# Altium schematic sheet 03-10715_Rev_0.1_Sheet_3.SchDoc
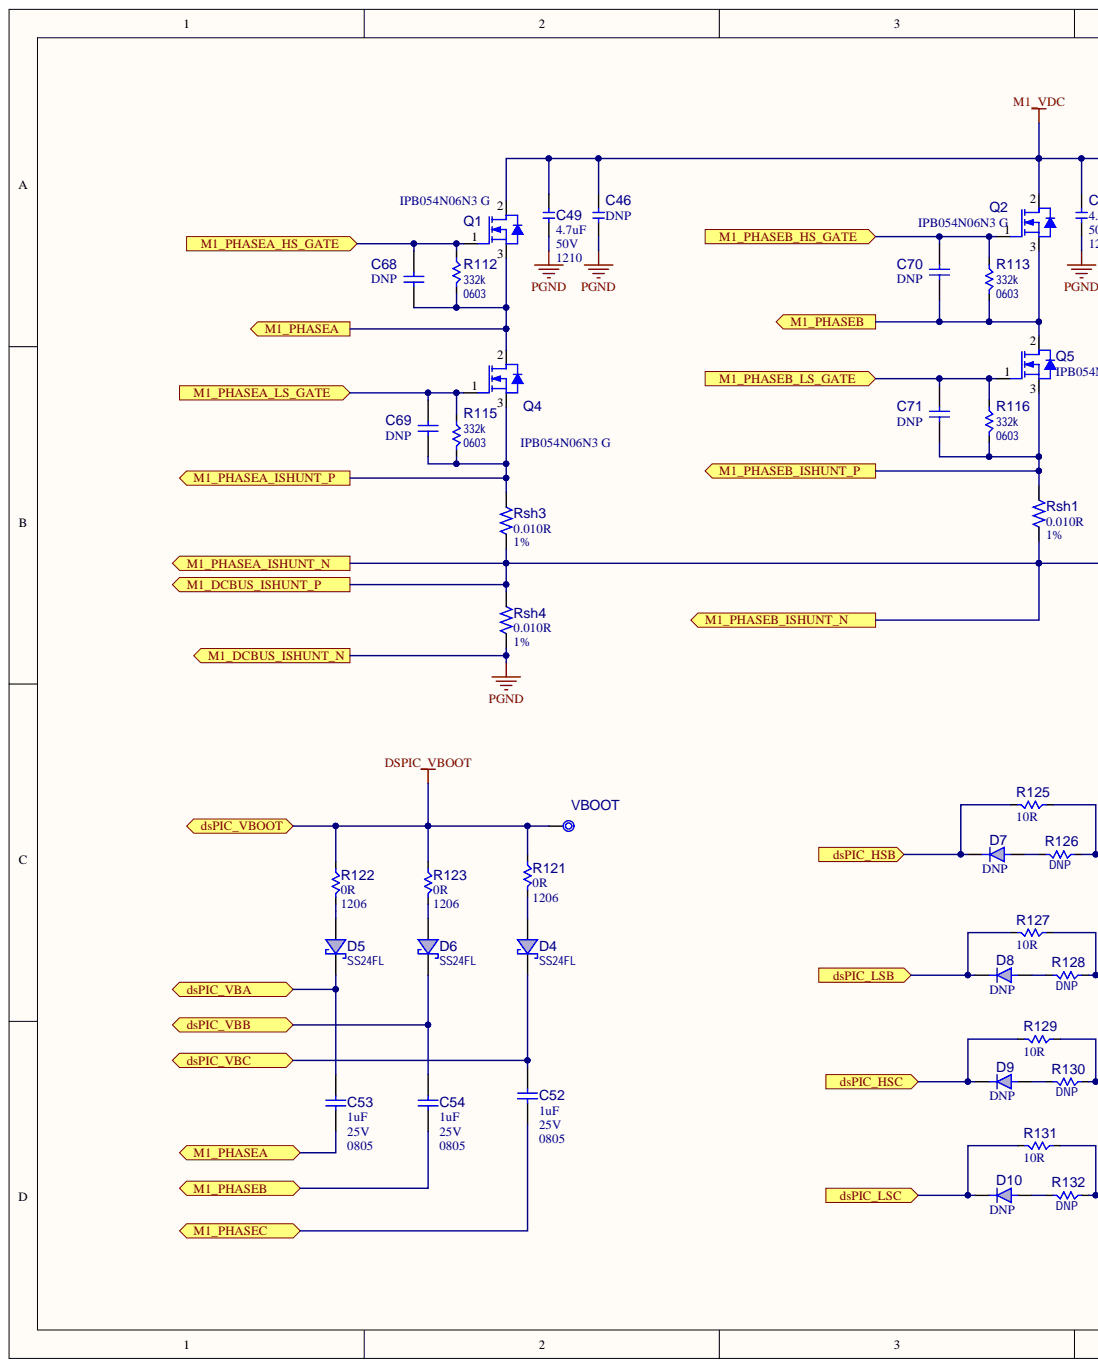
[diagram p0: 03-10715_Rev_0.1_Sheet_3.SchDoc, left]
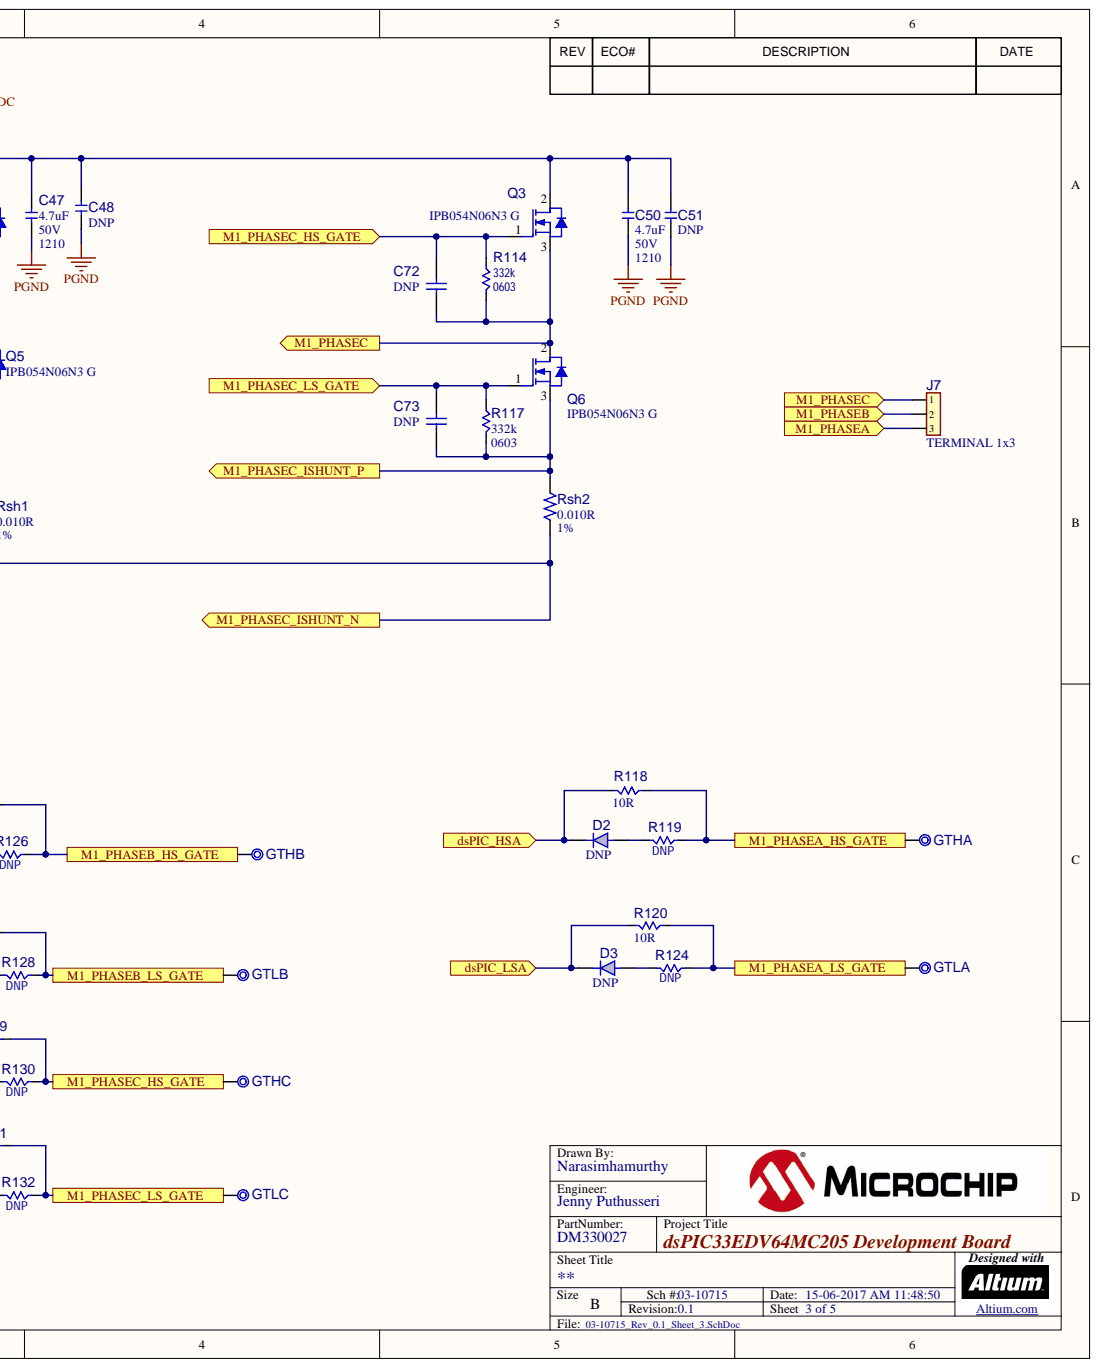
[diagram p1: 03-10715_Rev_0.1_Sheet_3.SchDoc, right]

## Parts
C46  value 4.7uF  Populated: DNP  [CAP_C_1210]  (hidden comment: Capacitor NP)
C47  value 4.7uF  Package: 1210  Voltage: 50V  [CAP_C_1210]  (hidden comment: Capacitor NP)
C48  Populated: DNP  [CAP_C_1210]  (hidden comment: Capacitor NP)
C49  value 4.7uF  Package: 1210  Voltage: 50V  [CAP_C_1210]  (hidden comment: Capacitor NP)
C50  value 4.7uF  Package: 1210  Voltage: 50V  [CAP_C_1210]  (hidden comment: Capacitor NP)
C51  value 4.7uF  Package: 1210  Populated: DNP  [CAP_C_1210]  (hidden comment: Capacitor NP)
C52  value 1uF  Package: 0805  Voltage: 25V  [CAP_C_0805]  (hidden comment: Capacitor NP)
C53  value 1uF  Package: 0805  Voltage: 25V  [CAP_C_0805]  (hidden comment: Capacitor NP)
C54  value 1uF  Package: 0805  Voltage: 25V  [CAP_C_0805]  (hidden comment: Capacitor NP)
C68  Populated: DNP  [CAP_C_0603]  (hidden comment: Capacitor NP)
C69  Populated: DNP  [CAP_C_0603]  (hidden comment: Capacitor NP)
C70  Populated: DNP  [CAP_C_0603]  (hidden comment: Capacitor NP)
C71  Populated: DNP  [CAP_C_0603]  (hidden comment: Capacitor NP)
C72  Populated: DNP  [CAP_C_0603]  (hidden comment: Capacitor NP)
C73  Populated: DNP  [CAP_C_0603]  (hidden comment: Capacitor NP)
D2  Populated: DNP  [SOD-323]  (hidden comment: Diode Single)
D3  Populated: DNP  [SOD-323]  (hidden comment: Diode Single)
D4  value SS24FL  Manufacturer Part Number 1: SS24FL  [SOD-123]  (hidden comment: Diode Schottky)
D5  value SS24FL  Manufacturer Part Number 1: SS24FL  [SOD-123]  (hidden comment: Diode Schottky)
D6  value SS24FL  Manufacturer Part Number 1: SS24FL  [SOD-123]  (hidden comment: Diode Schottky)
D7  Populated: DNP  [SOD-323]  (hidden comment: Diode Single)
D8  Populated: DNP  [SOD-323]  (hidden comment: Diode Single)
D9  Populated: DNP  [SOD-323]  (hidden comment: Diode Single)
D10  Populated: DNP  [SOD-323]  (hidden comment: Diode Single)
GTHA  Test Point No BOM  [TP_1.2x0.7]  (value not drawn)  (no BOM)
GTHB  Test Point No BOM  [TP_1.2x0.7]  (value not drawn)  (no BOM)
GTHC  Test Point No BOM  [TP_1.2x0.7]  (value not drawn)  (no BOM)
GTLA  Test Point No BOM  [TP_1.2x0.7]  (value not drawn)  (no BOM)
GTLB  Test Point No BOM  [TP_1.2x0.7]  (value not drawn)  (no BOM)
GTLC  Test Point No BOM  [TP_1.2x0.7]  (value not drawn)  (no BOM)
J7  value TERMINAL 1x3  [CON_TERMINAL_5_1x3_TH_RA_OST_OSTVI030152]  (hidden comment: Header 1x3)
Q1  value IPB054N06N3 G  Manufacturer Part Number 1: IPB054N06N3 G  [TO-263-3]  (hidden comment: Transistor MOS-N GDS)
Q2  value IPB054N06N3 G  Manufacturer Part Number 1: IPB054N06N3 G  [TO-263-3]  (hidden comment: Transistor MOS-N GDS)
Q3  value IPB054N06N3 G  Manufacturer Part Number 1: IPB054N06N3 G  [TO-263-3]  (hidden comment: Transistor MOS-N GDS)
Q4  value IPB054N06N3 G  [TO-263-3]  (hidden comment: Transistor MOS-N GDS)
Q5  value IPB054N06N3 G  [TO-263-3]  (hidden comment: Transistor MOS-N GDS)
Q6  value IPB054N06N3 G  [TO-263-3]  (hidden comment: Transistor MOS-N GDS)
R112  value 332k  Package: 0603  [RES_C_0603]  (hidden comment: Resistor)
R113  value 332k  Package: 0603  [RES_C_0603]  (hidden comment: Resistor)
R114  value 332k  Package: 0603  [RES_C_0603]  (hidden comment: Resistor)
R115  value 332k  Package: 0603  [RES_C_0603]  (hidden comment: Resistor)
R116  value 332k  Package: 0603  [RES_C_0603]  (hidden comment: Resistor)
R117  value 332k  Package: 0603  [RES_C_0603]  (hidden comment: Resistor)
R118  value 10R  [RES_C_0603]  (hidden comment: RC_0805)
R119  Populated: DNP  [RES_C_0603]  (hidden comment: RC_0805_No Load)
R120  value 10R  [RES_C_0603]  (hidden comment: RC_0805)
R121  value 0R  Package: 1206  [RES_C_1206]  (hidden comment: Resistor)
R122  value 0R  Package: 1206  [RES_C_1206]  (hidden comment: Resistor)
R123  value 0R  Package: 1206  [RES_C_1206]  (hidden comment: Resistor)
R124  Populated: DNP  [RES_C_0603]  (hidden comment: RC_0805_No Load)
R125  value 10R  [RES_C_0603]  (hidden comment: RC_0805)
R126  Populated: DNP  [RES_C_0603]  (hidden comment: RC_0805_No Load)
R127  value 10R  [RES_C_0603]  (hidden comment: RC_0805)
R128  Populated: DNP  [RES_C_0603]  (hidden comment: RC_0805_No Load)
R129  value 10R  [RES_C_0603]  (hidden comment: RC_0805)
R130  Populated: DNP  [RES_C_0603]  (hidden comment: RC_0805_No Load)
R131  value 10R  [RES_C_0603]  (hidden comment: RC_0805)
R132  Populated: DNP  [RES_C_0603]  (hidden comment: RC_0805_No Load)
Rsh1  value 0.010R  Tolerance: 1%  [RES_TTE_OARS-3]  (hidden comment: Resistor)
Rsh2  value 0.010R  Tolerance: 1%  [RES_TTE_OARS-3]  (hidden comment: Resistor)
Rsh3  value 0.010R  Tolerance: 1%  [RES_TTE_OARS-3]  (hidden comment: Resistor)
Rsh4  value 0.010R  Tolerance: 1%  [RES_TTE_OARS-3]  (hidden comment: Resistor)
VBOOT  VBOOT  [TP_1.2x0.7]  (no BOM)

## Ports
dsPIC_HSA, dsPIC_HSB, dsPIC_HSC, dsPIC_LSA, dsPIC_LSB, dsPIC_LSC, dsPIC_VBA, dsPIC_VBB, dsPIC_VBC, dsPIC_VBOOT, M1_DCBUS_ISHUNT_N, M1_DCBUS_ISHUNT_P, M1_PHASEA, M1_PHASEA_HS_GATE, M1_PHASEA_ISHUNT_N, M1_PHASEA_ISHUNT_P, M1_PHASEA_LS_GATE, M1_PHASEB, M1_PHASEB_HS_GATE, M1_PHASEB_ISHUNT_N, M1_PHASEB_ISHUNT_P, M1_PHASEB_LS_GATE, M1_PHASEC, M1_PHASEC_HS_GATE, M1_PHASEC_ISHUNT_N, M1_PHASEC_ISHUNT_P, M1_PHASEC_LS_GATE

## Nets
M1_VDC: C46.1 C47.1 C48.1 C49.1 C50.1 C51.1 Q1.2 Q2.2 Q3.2
dsPIC_HSA: D2.2 R118.1 ; port dsPIC_HSA
dsPIC_HSB: D7.2 R125.1 ; port dsPIC_HSB
dsPIC_HSC: D9.2 R129.1 ; port dsPIC_HSC
dsPIC_LSA: D3.2 R120.1 ; port dsPIC_LSA
dsPIC_LSB: D8.2 R127.1 ; port dsPIC_LSB
dsPIC_LSC: D10.2 R131.1 ; port dsPIC_LSC
dsPIC_VBA: C53.2 D5.2 ; port dsPIC_VBA
dsPIC_VBB: C54.2 D6.2 ; port dsPIC_VBB
dsPIC_VBC: C52.2 D4.2 ; port dsPIC_VBC
DSPIC_VBOOT: R121.2 R122.2 R123.2 VBOOT.1 ; port dsPIC_VBOOT
M1_DCBUS_ISHUNT_P: Rsh1.1 Rsh2.1 Rsh3.1 Rsh4.2 ; port M1_DCBUS_ISHUNT_P, port M1_PHASEA_ISHUNT_N, port M1_PHASEB_ISHUNT_N, port M1_PHASEC_ISHUNT_N
M1_PHASEA: C53.1 C68.1 J7.3 Q1.3 Q4.2 R112.2 ; port M1_PHASEA
M1_PHASEA_HS_GATE: C68.2 GTHA.1 Q1.1 R112.1 R118.2 R119.2 ; port M1_PHASEA_HS_GATE
M1_PHASEA_ISHUNT_P: C69.1 Q4.3 R115.2 Rsh3.2 ; port M1_PHASEA_ISHUNT_P
M1_PHASEA_LS_GATE: C69.2 GTLA.1 Q4.1 R115.1 R120.2 R124.2 ; port M1_PHASEA_LS_GATE
M1_PHASEB: C54.1 C70.1 J7.2 Q2.3 Q5.2 R113.2 ; port M1_PHASEB
M1_PHASEB_HS_GATE: C70.2 GTHB.1 Q2.1 R113.1 R125.2 R126.2 ; port M1_PHASEB_HS_GATE
M1_PHASEB_ISHUNT_P: C71.1 Q5.3 R116.2 Rsh1.2 ; port M1_PHASEB_ISHUNT_P
M1_PHASEB_LS_GATE: C71.2 GTLB.1 Q5.1 R116.1 R127.2 R128.2 ; port M1_PHASEB_LS_GATE
M1_PHASEC: C52.1 C72.1 J7.1 Q3.3 Q6.2 R114.2 ; port M1_PHASEC
M1_PHASEC_HS_GATE: C72.2 GTHC.1 Q3.1 R114.1 R129.2 R130.2 ; port M1_PHASEC_HS_GATE
M1_PHASEC_ISHUNT_P: C73.1 Q6.3 R117.2 Rsh2.2 ; port M1_PHASEC_ISHUNT_P
M1_PHASEC_LS_GATE: C73.2 GTLC.1 Q6.1 R117.1 R131.2 R132.2 ; port M1_PHASEC_LS_GATE
PGND: C46.2 C47.2 C48.2 C49.2 C50.2 C51.2 Rsh4.1 ; port M1_DCBUS_ISHUNT_N
NetD2_1: D2.1 R119.1
NetD3_1: D3.1 R124.1
NetD4_1: D4.1 R121.1
NetD5_1: D5.1 R122.1
NetD6_1: D6.1 R123.1
NetD7_1: D7.1 R126.1
NetD8_1: D8.1 R128.1
NetD9_1: D9.1 R130.1
NetD10_1: D10.1 R132.1

## Library data of the parts
C46 C51 = CAP1252: Capacitor; Manufacturer 1: Murata Electronics North America; Manufacturer Part Number 1: GRM32ER71H475KA88L; Description_: CAP CER 4.7uF 50V 10% X7R SMD 1210; Owner: Stephen Humberd; Temperature Coeffcient: X7R; Tolerance: 10%; Type: Ceramic; Voltage: 50V; Category: Capacitor; Component Kind: Standard; ComponentLink1Description: Datasheet; ComponentLink1URL: https://chn-vm-apps.microchip.com/mchprepo/parts/Datasheets/L0005E.pdf; Database Table Name: Capacitor; Library Name: MCHP-CDB.DbLib; MCHP-ID: CAP1252; Operating Temperature: -55°C ~ 125°C; Quantity Override: 1; Revision: A; Status: Design; Supplier 1: Digi-Key; Supplier Part Number 1: 490-1864-1-ND
  C46: Package: 1210
C47 C49 C50 = CAP1252: Capacitor; Manufacturer 1: Murata Electronics North America; Manufacturer Part Number 1: GRM32ER71H475KA88L; Description_: CAP CER 4.7uF 50V 10% X7R SMD 1210; Owner: Stephen Humberd; Populated: YES; Temperature Coeffcient: X7R; Tolerance: 10%; Type: Ceramic; Category: Capacitor; Component Kind: Standard; ComponentLink1Description: Datasheet; ComponentLink1URL: https://chn-vm-apps.microchip.com/mchprepo/parts/Datasheets/L0005E.pdf; Database Table Name: Capacitor; Library Name: MCHP-CDB.DbLib; MCHP-ID: CAP1252; Operating Temperature: -55°C ~ 125°C; Quantity Override: 1; Revision: A; Status: Design; Supplier 1: Digi-Key; Supplier Part Number 1: 490-1864-1-ND
C48 = CAP1252: Capacitor; Manufacturer 1: Murata Electronics North America; Manufacturer Part Number 1: GRM32ER71H475KA88L; Description_: CAP CER 4.7uF 50V 10% X7R SMD 1210; Owner: Stephen Humberd; Temperature Coeffcient: X7R; Tolerance: 10%; Type: Ceramic; Value: 4.7uF; Voltage: 50V; Category: Capacitor; Component Kind: Standard; ComponentLink1Description: Datasheet; ComponentLink1URL: https://chn-vm-apps.microchip.com/mchprepo/parts/Datasheets/L0005E.pdf; Database Table Name: Capacitor; Library Name: MCHP-CDB.DbLib; MCHP-ID: CAP1252; Operating Temperature: -55°C ~ 125°C; Package: 1210; Quantity Override: 1; Revision: A; Status: Design; Supplier 1: Digi-Key; Supplier Part Number 1: 490-1864-1-ND
C52-C54 = CAP1211: Capacitor; Manufacturer 1: AVX; Manufacturer Part Number 1: 08053C105K4Z2A; Description_: CAP CER 1uF 25V 10% X7R SMD 0805; Dev Tools Status: Production; Owner: Tad Ahlvers; Populated: YES; Temperature Coeffcient: X7R; Tolerance: 10%; Type: Ceramic; Category: Capacitor; Component Kind: Standard; ComponentLink1Description: SVN Datasheet; ComponentLink1URL: https://chn-vm-apps.microchip.com/mchprepo/parts/Datasheets/flexiterm.pdf; ComponentLink2Description: Local Datasheet; ComponentLink3Description: Open Symbol; ComponentLink4Description: Open Footprint; ComponentLink5Description: Open Footprint 2; ComponentLink6Description: Open Footprint 3; ComponentLink7Description: Open Footprint 4; Database Table Name: Capacitor; Library Name: MCHP-CDB.DbLib; Manufacturer 2: KEMET; Manufacturer 3: TDK Corporation; Manufacturer Part Number 2: C0805C105K3RACTU; Manufacturer Part Number 3: C2012X7R1E105K125AB; MCHP-ID: CAP1211; Operating Temperature: -55C to +125C; Quantity Override: 1; Revision: 1; Status: MCL Design; Supplier 1: Digi-Key; Supplier 2: Digi-Key; Supplier 3: Digi-Key; Supplier Part Number 1: 478-6357-2-ND; Supplier Part Number 2: C0805C105K3RACTU-ND; Supplier Part Number 3: 445-1354-1-ND; Symbol: Capacitor NP; ComponentLink2URL: C:\ALTIUM_WORK\00001_MCHP-CDB\Datasheets\flexiterm.pdf; ComponentLink3URL: C:\ALTIUM_WORK\00001_MCHP-CDB\Library\LibraryRefs\Capacitor NP.SchLib; ComponentLink4URL: C:\ALTIUM_WORK\00001_MCHP-CDB\Library\Footprints\CAP_C_0805.PcbLib
C68-C73 = CAP1116: Capacitor; Manufacturer 1: KEMET; Manufacturer Part Number 1: C0603C472K5RACTU; Description_: CAP CER 4700pF 50V 10% X7R SMD 0603; Dev Tools Status: Production; Owner: Miahi T(M91442); Temperature Coeffcient: X7R; Tolerance: 10%; Type: Ceramic; Value: 4700pF; Voltage: 50V; Category: Capacitor; Component Kind: Standard; ComponentLink1Description: Datasheet; ComponentLink1URL: https://chn-vm-apps.microchip.com/mchprepo/parts/Datasheets/KEM_C1002_X7R_SMD.pdf; Database Table Name: Capacitor; Library Name: MCHP-CDB.DbLib; MCHP-ID: CAP1116; Operating Temperature: -55C to +125C; Package: 0603; Quantity Override: 1; Revision: 0; Status: MCL Design; Supplier 1: Digi-Key; Supplier 2: Farnell; Supplier Part Number 1: 399-1087-1-ND; Supplier Part Number 2: 1414642; Symbol: Capacitor NP
D2 D3 D7-D10 = DIODE0096: Manufacturer 1: Diodes Incorporated; Manufacturer Part Number 1: 1N4148WS-7; Description_: DIO RECT 1N4148 855mV 300mA 75V SOD-323; Forward Current: 300mA; Power: 200mW; Reverse Current: 50uA; Reverse Voltage: 75V; Type: Rectifier Single; Value: 1N4148; Category: Diode; Component Kind: Standard; ComponentLink1Description: Datasheet; ComponentLink1URL: https://chn-vm-apps.microchip.com/mchprepo/parts/Datasheets/ds30097.pdf; Database Table Name: Diode; Library Name: MCHP-CDB.DbLib; Manufacturer 2: Diodes Incorporated; Manufacturer Part Number 2: 1N4148WS-7-F; MCHP-ID: DIODE0096; Operating Temperature: -65C to +150C; Package: SOD-323; Quantity Override: 1; Revision: 1; Status: Production; Supplier 1: Digi-Key; Supplier 2: Digi-Key; Supplier Part Number 1: 1N4148WSDITR-ND; Supplier Part Number 2: 1N4148WS-FDICT-ND
D4-D6 = DIODE1324: Manufacturer 1: Fairchild/ON Semiconductor; Description_: DIO SCTKY SS24FL 40V 2A SOD-123F; Dev Tools Status: Design; Forward Current: 2A; Owner: Mark Loper; Populated: YES; Reverse Voltage: 40V; Type: Schottky Single; Category: Diode; Component Kind: Standard; ComponentLink1Description: SVN Datasheet; ComponentLink1URL: https://chn-vm-apps.microchip.com/mchprepo/parts/Datasheets/SS24FL.pdf; ComponentLink2Description: Local Datasheet; ComponentLink3Description: Open Symbol; ComponentLink4Description: Open Footprint; ComponentLink5Description: Open Footprint 2; ComponentLink6Description: Open Footprint 3; ComponentLink7Description: Open Footprint 4; Database Table Name: Diode; Library Name: MCHP-CDB.DbLib; MCHP-ID: DIODE1324; Package: SOD-123; Quantity Override: 1; Revision: A; Status: MCL Design; Supplier 1: Digi-Key; Supplier Part Number 1: SS24FLCT-ND; Symbol: Diode Schottky; ComponentLink2URL: C:\ALTIUM_WORK\00001_MCHP-CDB\Datasheets\SS24FL.pdf; ComponentLink3URL: C:\ALTIUM_WORK\00001_MCHP-CDB\Library\LibraryRefs\Diode Schottky.SchLib; ComponentLink4URL: C:\ALTIUM_WORK\00001_MCHP-CDB\Library\Footprints\SOD-123.PcbLib
GTHA GTHB GTHC GTLA GTLB GTLC VBOOT = CON1128: Test Point; Database Table Name: Connector; Description_: CON TP Via_1.2x0.7; Owner: Bogdan P (M91398); Populated: Yes; Value: 1x1; Category: Connector; ComponentLink1Description: Datasheet; Library Name: MCHP-CDB.DbLib; MCHP-ID: CON1128; Quantity Override: 1; Revision: 0; Status: Production; Type: Test Point
J7 = CON1186: Manufacturer 1: On Shore Technology Inc; Manufacturer Part Number 1: OSTVI030152; Current: 16A; Database Table Name: Connector; Description_: CON TERMINAL 5mm 1x3 Female 12-28AWG 16A TH R/A; Dev Tools Status: Production; Owner: Stephen Humberd; Populated: YES; Voltage: 300V; Category: Connector; Component Kind: Standard; ComponentLink1Description: Datasheet; ComponentLink1URL: https://chn-vm-apps.microchip.com/mchprepo/parts/Datasheets/ostvixx0152.pdf; Library Name: MCHP-CDB.DbLib; MCHP-ID: CON1186; Quantity Override: 1; Revision: A; Status: MCL Design; Supplier 1: Digi-Key; Supplier Part Number 1: ED2729-ND; Symbol: Header 1x3; Type: Terminal Block
Q1-Q6 = TRA1037: Transistor MOS; Manufacturer 1: Infineon Technologies; Current: 80A; Description_: TRANS FET N-CH IPB054N06N3 G 60V 80A 115W TO-263-3; Dev Tools Status: Production; Drain to Source Voltage: 60V; Owner: Stephen Humberd; Populated: YES; Power: 115W; Type: FET; Category: Transistor; Component Kind: Standard; ComponentLink1Description: Datasheet; ComponentLink1URL: https://chn-vm-apps.microchip.com/mchprepo/parts/Datasheets/IPP_B057N06N3_Rev2.0.pdf; Database Table Name: Transistor; Library Name: MCHP-CDB.DbLib; MCHP-ID: TRA1037; Package: TO-263; Quantity Override: 1; Revision: A; Status: MCL Design; Supplier 1: Digi-Key; Supplier Part Number 1: IPB054N06N3 GCT-ND; Symbol: Transistor MOS-N GDS; Voltage: 60V
  Q4-Q6: Manufacturer Part Number 1: IPB054N06N3 G
R112-R117 = RES1168: Manufacturer 1: Panasonic; Manufacturer Part Number 1: ERJ-3EKF3323V; Description_: RES TKF 332k 1% 1/10W SMD 0603; Owner: Bogdan P (M91398); Populated: Yes; Power: 1/10W; Temperature Coeffcient: 100ppm/C; Tolerance: 1%; Voltage: 75V; Category: Resistor; Component Kind: Standard; ComponentLink1Description: Datasheet; ComponentLink1URL: https://chn-vm-apps.microchip.com/mchprepo/parts/Datasheets/AOA0000CE2.pdf; Composition: TKF; Database Table Name: Resistor; Description: Resistor; Library Name: MCHP-CDB.DbLib; MCHP-ID: RES1168; Operating Temperature: -55C to +155C; Quantity Override: 1; Revision: 0; Status: Production; Supplier 1: Digi-Key; Supplier Part Number 1: P332KHCT-ND; Type: RES SMD
R118 R120 R125 R127 R129 R131 = RSMT0456: Resistor; Manufacturer 1: Panasonic; Manufacturer Part Number 1: ERJ-3EKF10R0V; Description_: RES TKF 10R 1% 1/10W SMD 0603; Populated: Yes; Power: 1/10W; Temperature Coeffcient: 100ppm/C; Tolerance: 1%; Voltage: 75V; Category: Resistor; Component Kind: Standard; ComponentLink1Description: Datasheet; ComponentLink1URL: https://chn-vm-apps.microchip.com/mchprepo/parts/Datasheets/AOA0000CE2.pdf; Composition: TKF; Database Table Name: Resistor; Library Name: MCHP-CDB.DbLib; Manufacturer 2: SET; Manufacturer 3: Yageo; Manufacturer Part Number 2: RMCF1/1610.01%TR; Manufacturer Part Number 3: RC0603FR-0710RL; MCHP-ID: RSMT0456; Operating Temperature: -55C to +155C; Package: 0603; Quantity Override: 1; Revision: 2; Status: Production; Supplier 1: Digi-Key; Supplier 2: SET; Supplier 3: Digi-Key; Supplier Part Number 1: P10.0HTR-ND; Supplier Part Number 2: RMCF1/1610.01%TR; Supplier Part Number 3: 311-10.0HRCT-ND; Type: RES SMD
R119 R124 R126 R128 R130 R132 = RSMT0001: Resistor; Manufacturer 1: NIC Components; Manufacturer Part Number 1: NRC06Z0TRF; Description_: RES TKF 0R 1/10W SMD 0603; Power: 1/10W; Value: 0R; Category: Resistor; Component Kind: Standard; ComponentLink1Description: Datasheet; ComponentLink1URL: https://chn-vm-apps.microchip.com/mchprepo/parts/Datasheets/nrc.pdf; Composition: TKF; Database Table Name: Resistor; Library Name: MCHP-CDB.DbLib; Manufacturer 2: Panasonic; Manufacturer 3: Panasonic; Manufacturer 4: Stackpole Electronics Inc; Manufacturer 5: Vishay; Manufacturer 6: Stackpole Electronics Inc; Manufacturer 7: Yageo; Manufacturer 8: KOA Speer; Manufacturer 9: ROHM; Manufacturer Part Number 2: ERJ-3GSY0R00V; Manufacturer Part Number 3: ERJ-3GEY0R00V; Manufacturer Part Number 4: RMCF0603ZT0R00; Manufacturer Part Number 5: CRCW06030000Z0EA; Manufacturer Part Number 6: RMCF 1/16 0 R; Manufacturer Part Number 7: RC0603JR-070RL; Manufacturer Part Number 8: RK73Z1JTTP; Manufacturer Part Number 9: MCR03EZPJ000; MCHP-ID: RSMT0001; Operating Temperature: -55C to +155C; Package: 0603; Quantity Override: 1; Revision: 2; Status: Production; Supplier 1: NIC Components; Supplier 2: Digi-Key; Supplier 3: Digi-Key; Supplier 4: Digi-Key; Supplier 5: Digi-Key; Supplier 6: Digi-Key; Supplier 7: Digi-Key; Supplier 8: KOA Speer; Supplier 9: Digi-Key; Supplier Part Number 1: NRC06Z0TRF; Supplier Part Number 2: P0.0GTR-ND; Supplier Part Number 3: RMCF0603ZT0R00TR-ND; Supplier Part Number 4: RMCF0603ZT0R00CT-ND; Supplier Part Number 5: 541-0.0GCT-ND; Supplier Part Number 6: RMCF1/160RTR-ND; Supplier Part Number 7: 311-0.0GRCT-ND; Supplier Part Number 8: RK73Z1JTTP; Supplier Part Number 9: RHM0.0GCT-ND; Type: RES SMD
R121-R123 = RSMT0003: Manufacturer 1: KOA Speer; Manufacturer Part Number 1: RK73Z2BTTD; Current: 2A; Description_: RES TKF 0R SMD 1206; Populated: Yes; Category: Resistor; Component Kind: Standard; ComponentLink1Description: Datasheet; ComponentLink1URL: https://chn-vm-apps.microchip.com/mchprepo/parts/Datasheets/AOA0000CE1.pdf; Composition: TKF; Database Table Name: Resistor; Description: Resistor; Library Name: MCHP-CDB.DbLib; Manufacturer 2: Panasonic; Manufacturer Part Number 2: ERJ-8GEY0R00V; MCHP-ID: RSMT0003; Quantity Override: 1; Revision: 1; Status: Production; Supplier 1: KOA Speer; Supplier 2: Digi-Key; Supplier Part Number 1: RK73Z2BTTD; Supplier Part Number 2: P0.0ETR-ND; Type: RES SMD
Rsh1-Rsh4 = RES1818: Manufacturer 1: IRC / TT Electronics; Manufacturer Part Number 1: OARS3R010FLF; Description_: RES SHUNT 0.010R 1% 3W SMD L11.18W3.18H3.81; Dev Tools Status: Design; Owner: Narasimha Murthy; Populated: YES; Power: 3W; Temperature Coeffcient: 40ppm/C; Category: Resistor; Component Kind: Standard; ComponentLink1Description: SVN Datasheet; ComponentLink1URL: https://chn-vm-apps.microchip.com/mchprepo/parts/Datasheets/OARS-3.pdf; ComponentLink2Description: Local Datasheet; ComponentLink3Description: Open Symbol; ComponentLink4Description: Open Footprint; ComponentLink5Description: Open Footprint 2; ComponentLink6Description: Open Footprint 3; ComponentLink7Description: Open Footprint 4; Composition: MS; Database Table Name: Resistor; Description: Resistor; Library Name: MCHP-CDB.DbLib; MCHP-ID: RES1818; Operating Temperature: - 40 C to + 125 C; Package: OARS-3; Quantity Override: 1; Revision: A; Status: MCL Design; Supplier 1: Mouser; Supplier Part Number 1: 66-OARS3R010FLF; Symbol: Resistor; Type: Shunt; ComponentLink2URL: C:\ALTIUM_WORK\00001_MCHP-CDB\Datasheets\OARS-3.pdf; ComponentLink3URL: C:\ALTIUM_WORK\00001_MCHP-CDB\Library\LibraryRefs\Resistor.SchLib; ComponentLink4URL: C:\ALTIUM_WORK\00001_MCHP-CDB\Library\Footprints\RES_TTE_OARS-3.PcbLib
